# Altium schematic sheet MainBoard_RELAY.SchDoc
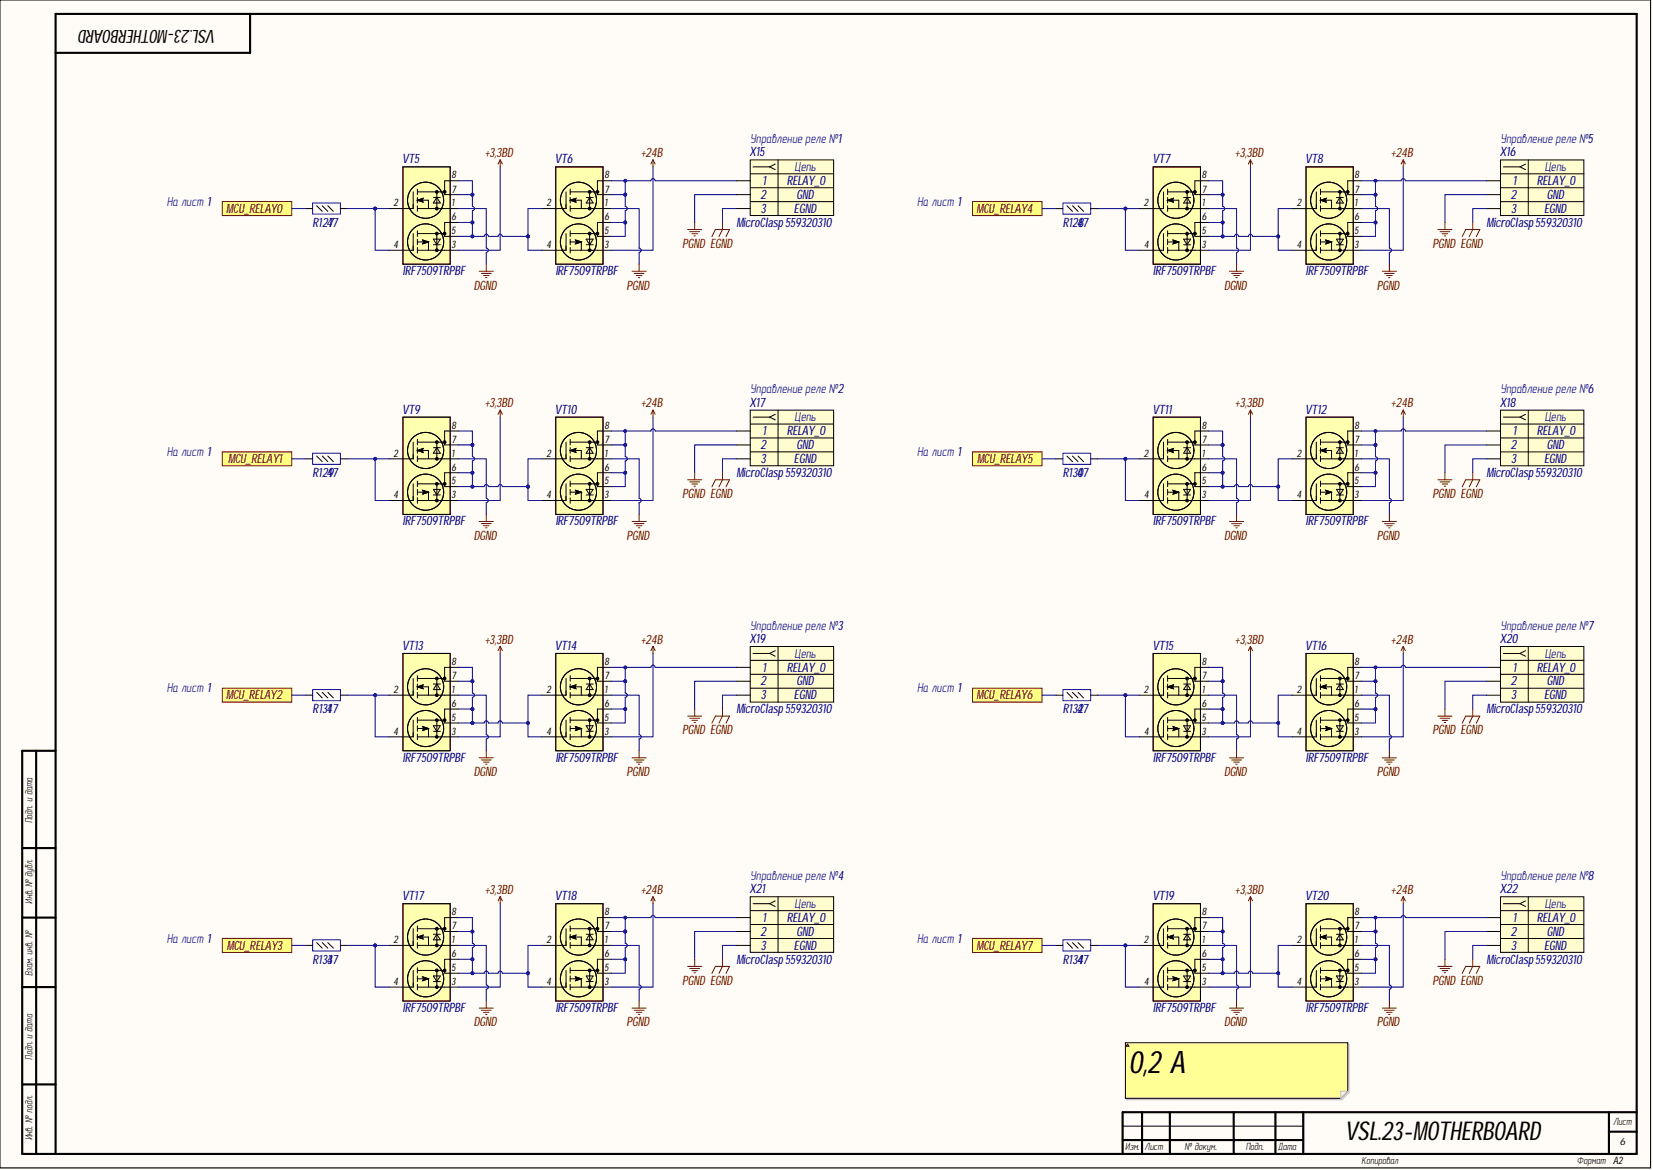
[diagram p0: MainBoard_RELAY.SchDoc]

## Parts
R127  value 47  [R0402]  (hidden comment: Resistor_0,062Вт)
R128  value 47  [R0402]  (hidden comment: Resistor_0,062Вт)
R129  value 47  [R0402]  (hidden comment: Resistor_0,062Вт)
R130  value 47  [R0402]  (hidden comment: Resistor_0,062Вт)
R131  value 47  [R0402]  (hidden comment: Resistor_0,062Вт)
R132  value 47  [R0402]  (hidden comment: Resistor_0,062Вт)
R133  value 47  [R0402]  (hidden comment: Resistor_0,062Вт)
R134  Resistor_0,062Вт  [R0402]  (value not drawn)
VT5  IRF7509TRPBF  [Micro8]
VT6  IRF7509TRPBF  [Micro8]
VT7  IRF7509TRPBF  [Micro8]
VT8  IRF7509TRPBF  [Micro8]
VT9  IRF7509TRPBF  [Micro8]
VT10  IRF7509TRPBF  [Micro8]
VT11  IRF7509TRPBF  [Micro8]
VT12  IRF7509TRPBF  [Micro8]
VT13  IRF7509TRPBF  [Micro8]
VT14  IRF7509TRPBF  [Micro8]
VT15  IRF7509TRPBF  [Micro8]
VT16  IRF7509TRPBF  [Micro8]
VT17  IRF7509TRPBF  [Micro8]
VT18  IRF7509TRPBF  [Micro8]
VT19  IRF7509TRPBF  [Micro8]
VT20  IRF7509TRPBF  [Micro8]
X15  Управление реле №1  Contact_1: RELAY_0  Contact_2: GND  Contact_3: EGND  Part Name: MicroClasp  Part Number: 559320310  [MOLEX_559320310]
X16  Управление реле №5  Contact_1: RELAY_0  Contact_2: GND  Contact_3: EGND  Part Name: MicroClasp  Part Number: 559320310  [MOLEX_559320310]
X17  Управление реле №2  Contact_1: RELAY_0  Contact_2: GND  Contact_3: EGND  Part Name: MicroClasp  Part Number: 559320310  [MOLEX_559320310]
X18  Управление реле №6  Contact_1: RELAY_0  Contact_2: GND  Contact_3: EGND  Part Name: MicroClasp  Part Number: 559320310  [MOLEX_559320310]
X19  Управление реле №3  Contact_1: RELAY_0  Contact_2: GND  Contact_3: EGND  Part Name: MicroClasp  Part Number: 559320310  [MOLEX_559320310]
X20  Управление реле №7  Contact_1: RELAY_0  Contact_2: GND  Contact_3: EGND  Part Name: MicroClasp  Part Number: 559320310  [MOLEX_559320310]
X21  Управление реле №4  Contact_1: RELAY_0  Contact_2: GND  Contact_3: EGND  Part Name: MicroClasp  Part Number: 559320310  [MOLEX_559320310]
X22  Управление реле №8  Contact_1: RELAY_0  Contact_2: GND  Contact_3: EGND  Part Name: MicroClasp  Part Number: 559320310  [MOLEX_559320310]

## Ports
MCU_RELAY0, MCU_RELAY1, MCU_RELAY2, MCU_RELAY3, MCU_RELAY4, MCU_RELAY5, MCU_RELAY6, MCU_RELAY7

## Nets
+3,3BD: VT5.3 VT7.3 VT9.3 VT11.3 VT13.3 VT15.3 VT17.3 VT19.3
+24B: VT6.3 VT8.3 VT10.3 VT12.3 VT14.3 VT16.3 VT18.3 VT20.3
DGND: VT5.1 VT7.1 VT9.1 VT11.1 VT13.1 VT15.1 VT17.1 VT19.1
EGND: X15.3 X16.3 X17.3 X18.3 X19.3 X20.3 X21.3 X22.3
PGND: VT6.1 VT8.1 VT10.1 VT12.1 VT14.1 VT16.1 VT18.1 VT20.1 X15.2 X16.2 X17.2 X18.2 X19.2 X20.2 X21.2 X22.2
MCU_RELAY0: R127.1 ; port MCU_RELAY0
MCU_RELAY1: R129.1 ; port MCU_RELAY1
MCU_RELAY2: R131.1 ; port MCU_RELAY2
MCU_RELAY3: R133.1 ; port MCU_RELAY3
MCU_RELAY4: R128.1 ; port MCU_RELAY4
MCU_RELAY5: R130.1 ; port MCU_RELAY5
MCU_RELAY6: R132.1 ; port MCU_RELAY6
MCU_RELAY7: R134.1 ; port MCU_RELAY7
NetR127_2: R127.2 VT5.2 VT5.4
NetR128_2: R128.2 VT7.2 VT7.4
NetR129_2: R129.2 VT9.2 VT9.4
NetR130_2: R130.2 VT11.2 VT11.4
NetR131_2: R131.2 VT13.2 VT13.4
NetR132_2: R132.2 VT15.2 VT15.4
NetR133_2: R133.2 VT17.2 VT17.4
NetR134_2: R134.2 VT19.2 VT19.4
NetVT5_5: VT5.5 VT5.6 VT5.7 VT5.8 VT6.2 VT6.4
NetVT6_5: VT6.5 VT6.6 VT6.7 VT6.8 X15.1
NetVT7_5: VT7.5 VT7.6 VT7.7 VT7.8 VT8.2 VT8.4
NetVT8_5: VT8.5 VT8.6 VT8.7 VT8.8 X16.1
NetVT9_5: VT9.5 VT9.6 VT9.7 VT9.8 VT10.2 VT10.4
NetVT10_5: VT10.5 VT10.6 VT10.7 VT10.8 X17.1
NetVT11_5: VT11.5 VT11.6 VT11.7 VT11.8 VT12.2 VT12.4
NetVT12_5: VT12.5 VT12.6 VT12.7 VT12.8 X18.1
NetVT13_5: VT13.5 VT13.6 VT13.7 VT13.8 VT14.2 VT14.4
NetVT14_5: VT14.5 VT14.6 VT14.7 VT14.8 X19.1
NetVT15_5: VT15.5 VT15.6 VT15.7 VT15.8 VT16.2 VT16.4
NetVT16_5: VT16.5 VT16.6 VT16.7 VT16.8 X20.1
NetVT17_5: VT17.5 VT17.6 VT17.7 VT17.8 VT18.2 VT18.4
NetVT18_5: VT18.5 VT18.6 VT18.7 VT18.8 X21.1
NetVT19_5: VT19.5 VT19.6 VT19.7 VT19.8 VT20.2 VT20.4
NetVT20_5: VT20.5 VT20.6 VT20.7 VT20.8 X22.1

## Library data of the parts
R127-R133 = res238: Резистор; Manufacture: Yageo; Part Number: RC0402FR-0747RL; Accuracy: 0,01; Database Table Name: Resistor$; Component Kind: Standard; id: res238; Library Name: MOP44.DbLib; Part Name: Resistor; Power: 0,062Вт
R134 = res238: Резистор; Manufacture: Yageo; Part Number: RC0402FR-0747RL; Accuracy: 0,01; Database Table Name: Resistor$; Value: 47; Component Kind: Standard; id: res238; Library Name: MOP44.DbLib; Part Name: Resistor; Power: 0,062Вт
VT5-VT20 = mosfert3: Комплементарная сборка МОП; Manufacturer: International Rectifier; Continuous drain current: 2A; Database Table Name: MOSFETTransistor$; Gate-to-source voltage: (+-)20B; Power dissipation: 1,25W; Pulsed drain current: 16A; Rds: 0,11Ohm; Structure: n-p-channel; V drain-source max: 30B; Component Kind: Standard; id: mosfert3; Library Name: MOP44.DbLib; Part Number: IRF7509TRPBF
X15-X22 = connect96: Разъем - 3 конт. розетка; Manufacture: Molex; Database Table Name: Connector$; Etch: 2mm; Component Kind: Standard; id: connect96; Library Name: MOP44.DbLib
